# Altium schematic sheet H16R7x_Frontend 1.SchDoc
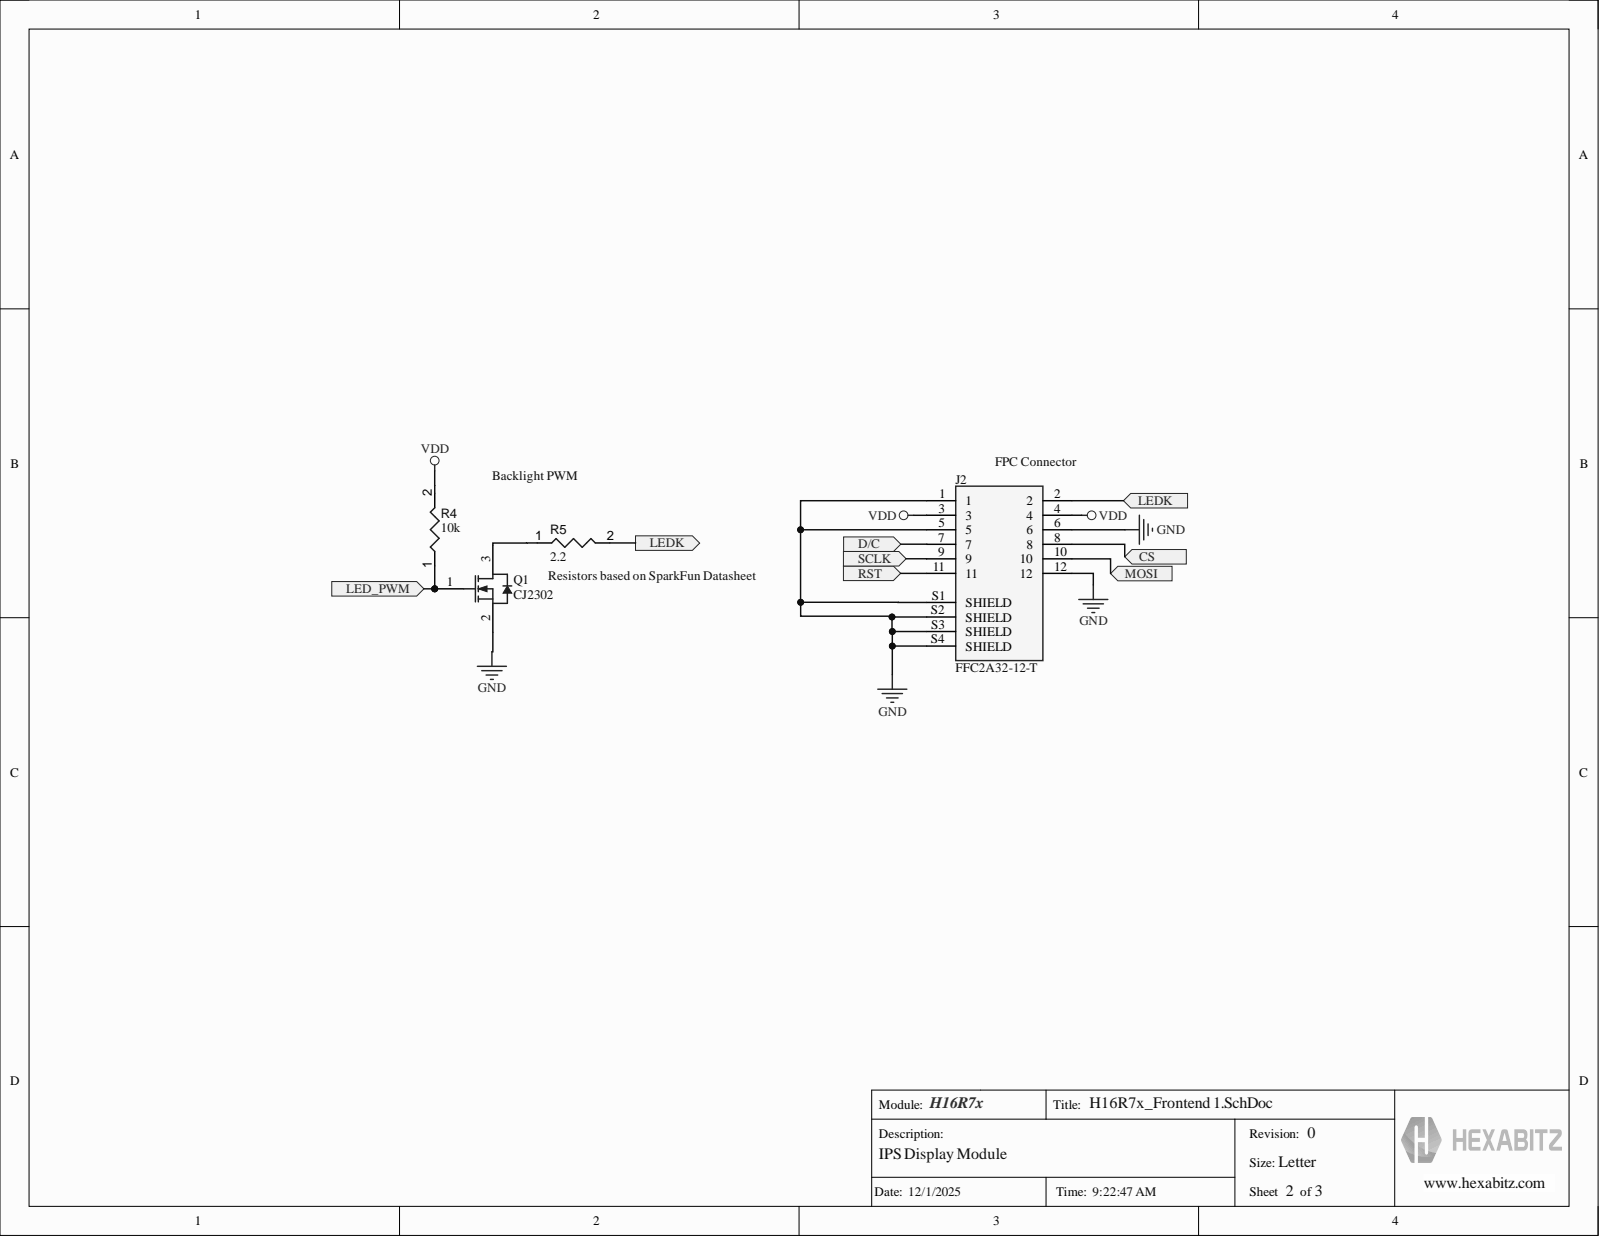
[diagram p0: H16R7x_Frontend 1.SchDoc]

## Parts
J2  FFC2A32-12-T  [GCT_FFC2A32-12-T]
Q1  CJ2302  [JSCJ-SOT23-3_V]
R4  value 10k  [RESC0402(1005)_L]  (hidden comment: 10KR2F)
R5  value 2.2  [RESC0402(1005)_L]  (hidden comment: Resistor 2R2  +/-5 % 0402 63 mW)

## Ports
CS, D/C, LED_PWM, LEDK, MOSI, RST, SCLK

## Nets
GND: J2.1 J2.5 J2.6 J2.12 J2.S1(SHIELD) J2.S2(SHIELD) J2.S3(SHIELD) J2.S4(SHIELD) Q1.2
VDD: J2.3 J2.4 R4.2
CS: J2.8 ; port CS
D/C: J2.7 ; port D/C
LED_PWM: Q1.1 R4.1 ; port LED_PWM
LEDK: J2.2 R5.2 ; port LEDK
MOSI: J2.10 ; port MOSI
RST: J2.11 ; port RST
SCLK: J2.9 ; port SCLK
NetQ1_3: Q1.3 R5.1

## Library data of the parts
J2 = CMP-002-00071-1: 12 Position FFC, FPC Connector Contacts, Vertical - 1 Sided 0.020 (0.50mm) Surface Mount; MF: Global Connector Technology; Case/Package: None; Check_prices: https://www.snapeda.com/parts/FFC2A32-12-T/Global+Connector+Technology/view-part/?ref=eda; Component Kind: Standard; Component Type: Standard; Design Item ID: FFC2A32-12-T; MP: FFC2A32-12-T; Pins: 16; SnapEDA_Link: https://www.snapeda.com/parts/FFC2A32-12-T/Global+Connector+Technology/view-part/?ref=snap; Source: FFC2A32-12-T.SchLib; Library Name: Hannah Nguyen
Q1 = CMP-013-00014-3: N-Channe Plastic Encapsulate MOSFET, 20 V, 2.1 A, 3-Pin SOT23, Tape and Reel; Manufacturer: Jiangsu Changjiang Electronics Technology; Package Version: Rev. E, 09/2009; Case/Package: SOT23-3; Component Kind: Standard; Datasheet URL: http://www.cj-elec.com/gallery/txUpfile/9c19fa03e8d43801a6647d386a41a240.pdf; Datasheet Version: Rev. D, 08/2015; Mounting Technology: SM; Package Description: 3-Pin Small Outline Transistor, Body 2.9 x 1.3 mm, Pitch 0.95 mm; Package Reference: SOT23-3; RoHS Compliant: Unknown; Library Name: Hannah Nguyen
R4 = CMP-009-00066-2: 10K 0.063W 1% 0402 (1005 Metric)  SMD; Power: 63mW; Case/Package: 0402; Component Kind: Standard; Mounting Technology: SMT; Pins: 2; Tolerance: 1%; Library Name: Hannah Nguyen
R5 = CMP-009-00128-3: Chip Resistor, 2,2 Ohms, +/-5 %, 63 mW, -55 to 155 degC, 0402; Power: 63mW; Tolerance: 5%; Case/Package: 0402; Component Kind: Standard; Max Operating Temperature: 155°C; Min Operating Temperature: -55°C; Pins: 2; Library Name: Hannah Nguyen
